# Altium schematic sheet HDMI.SchDoc
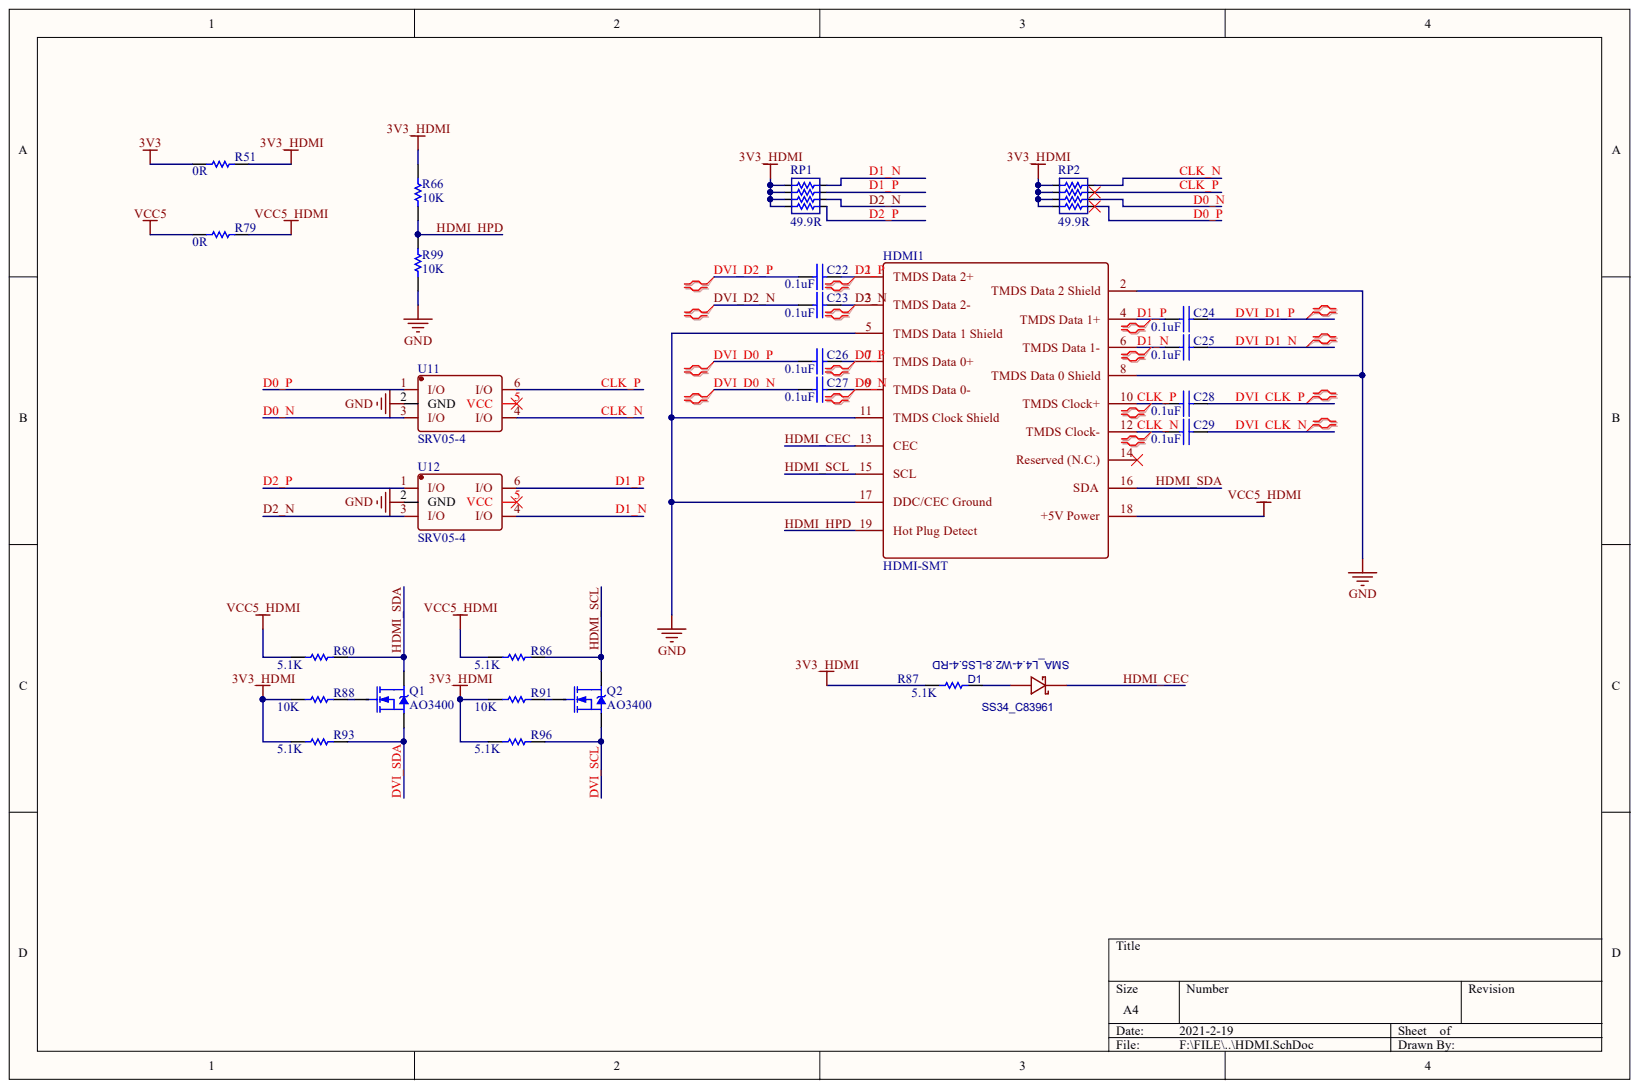
[diagram p0: HDMI.SchDoc]

## Parts
C22  0.1uF  [C_0402]
C23  0.1uF  [C_0402]
C24  0.1uF  [C_0402]
C25  0.1uF  [C_0402]
C26  0.1uF  [C_0402]
C27  0.1uF  [C_0402]
C28  0.1uF  [C_0402]
C29  0.1uF  [C_0402]
D1  SS34_C83961  [SMA_L4.4-W2.8-LS5.4-RD]
HDMI1  HDMI-SMT  [HDMI-SMT-19Pin]
Q1  AO3400  [SOT23]
Q2  AO3400  [SOT23]
R51  0R  [0603_Q0603A]
R66  10K  [R0402]
R79  0R  [0603_Q0603A]
R80  5.1K  [R0402]
R86  5.1K  [R0402]
R87  5.1K  [R0402]
R88  10K  [R0402]
R91  10K  [R0402]
R93  5.1K  [R0402]
R96  5.1K  [R0402]
R99  10K  [R0402]
RP1  49.9R  [贴片排阻]
RP2  49.9R  [贴片排阻]
U11  SRV05-4  [SOT23-6]
U12  SRV05-4  [SOT23-6]

## Nets
3V3: R51.2
3V3_HDMI: R51.1 R66.1 R87.1 R88.1 R91.1 R93.1 R96.1 RP1.1 RP1.2 RP1.3 RP1.4 RP2.1 RP2.2 RP2.3 RP2.4
GND: HDMI1.2(TMDS_Data_2_Shield) HDMI1.5(TMDS_Data_1_Shield) HDMI1.8(TMDS_Data_0_Shield) HDMI1.11(TMDS_Clock_Shield) HDMI1.17(DDC/CEC_Ground) R99.2 U11.2(GND) U12.2(GND)
VCC5: R79.2
VCC5_HDMI: HDMI1.18(+5V_Power) R79.1 R80.1 R86.1
CLK_N: C29.1 HDMI1.12(TMDS_Clock-) RP2.8 U11.4(I/O)
CLK_P: C28.1 HDMI1.10(TMDS_Clock+) RP2.7 U11.6(I/O)
D0_N: C27.2 HDMI1.9(TMDS_Data_0-) RP2.6 U11.3(I/O)
D0_P: C26.2 HDMI1.7(TMDS_Data_0+) RP2.5 U11.1(I/O)
D1_N: C25.1 HDMI1.6(TMDS_Data_1-) RP1.8 U12.4(I/O)
D1_P: C24.1 HDMI1.4(TMDS_Data_1+) RP1.7 U12.6(I/O)
D2_N: C23.2 HDMI1.3(TMDS_Data_2-) RP1.6 U12.3(I/O)
D2_P: C22.2 HDMI1.1(TMDS_Data_2+) RP1.5 U12.1(I/O)
DVI_CLK_N: C29.2
DVI_CLK_P: C28.2
DVI_D0_N: C27.1
DVI_D0_P: C26.1
DVI_D1_N: C25.2
DVI_D1_P: C24.2
DVI_D2_N: C23.1
DVI_D2_P: C22.1
DVI_SCL: Q2.S R96.2
DVI_SDA: Q1.S R93.2
HDMI_CEC: D1.1 HDMI1.13(CEC)
HDMI_HPD: HDMI1.19(Hot_Plug_Detect) R66.2 R99.1
HDMI_SCL: HDMI1.15(SCL) Q2.D R86.2
HDMI_SDA: HDMI1.16(SDA) Q1.D R80.2
NetD1_2: D1.2 R87.2
NetQ1_G: Q1.G R88.2
NetQ2_G: Q2.G R91.2

Not connected: HDMI1 14(Reserved_(N.C.)); U11 5(VCC); U12 5(VCC)

## Library data of the parts
C22-C29 = CAP: 无极性电容; Component Kind: Standard; Library Name: 电容.IntLib
D1 = SS34_C83961: BOM_Manufacturer: STARSEA; BOM_Manufacturer Part: SS34; Package Display: Yes; SMT Type: Extend; BOM_JLCPCB SMD: Yes; BOM_Supplier: LCSC; BOM_Supplier Part: C83961; Component Kind: Standard; image: //image.lceda.cn/szlcsc/C83961.jpg; spicePre: D; spiceSymbolName: SS34_C83961
Q1 Q2 = N-MOS: N沟道场效应管; Component Kind: Standard; Library Name: MOS管.IntLib
R51 R66 R79 R80 R86-R88 R91 R93 R96 R99 = RES: Component Kind: Standard; Library Name: 电阻.IntLib
RP1 RP2 = SMT_RES_PACK8: Component Kind: Standard; Library Name: 电阻.IntLib
HDMI1 = HDMI-SMT: Component Kind: Standard; Library Name: 视频接口.IntLib
U11 U12 = SRV05-4: Component Kind: Standard; Library Name: 未分类IC.IntLib
